# Altium schematic sheet Sheet1.SchDoc(Sheet1)
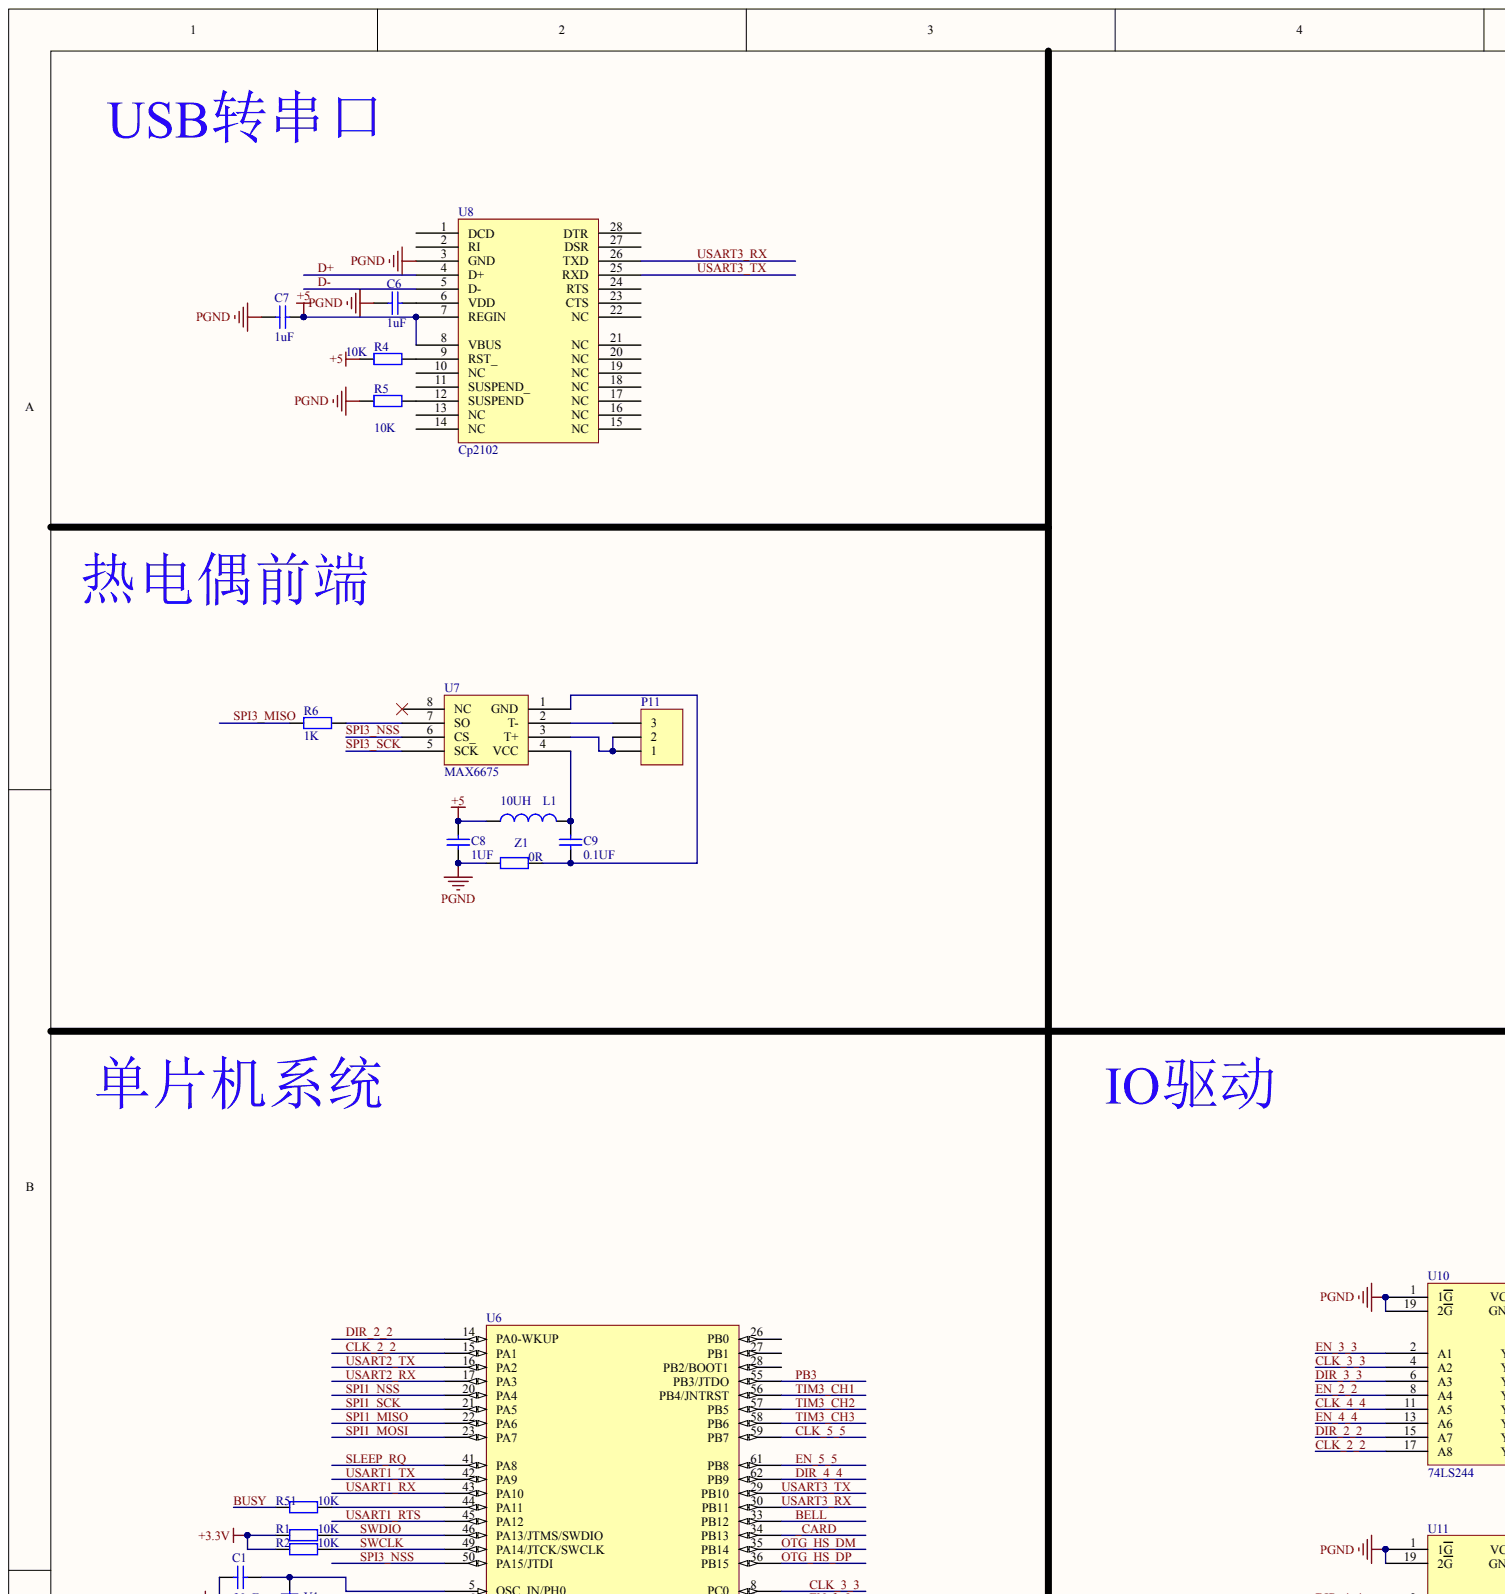
[diagram p0: Sheet1.SchDoc, top left]
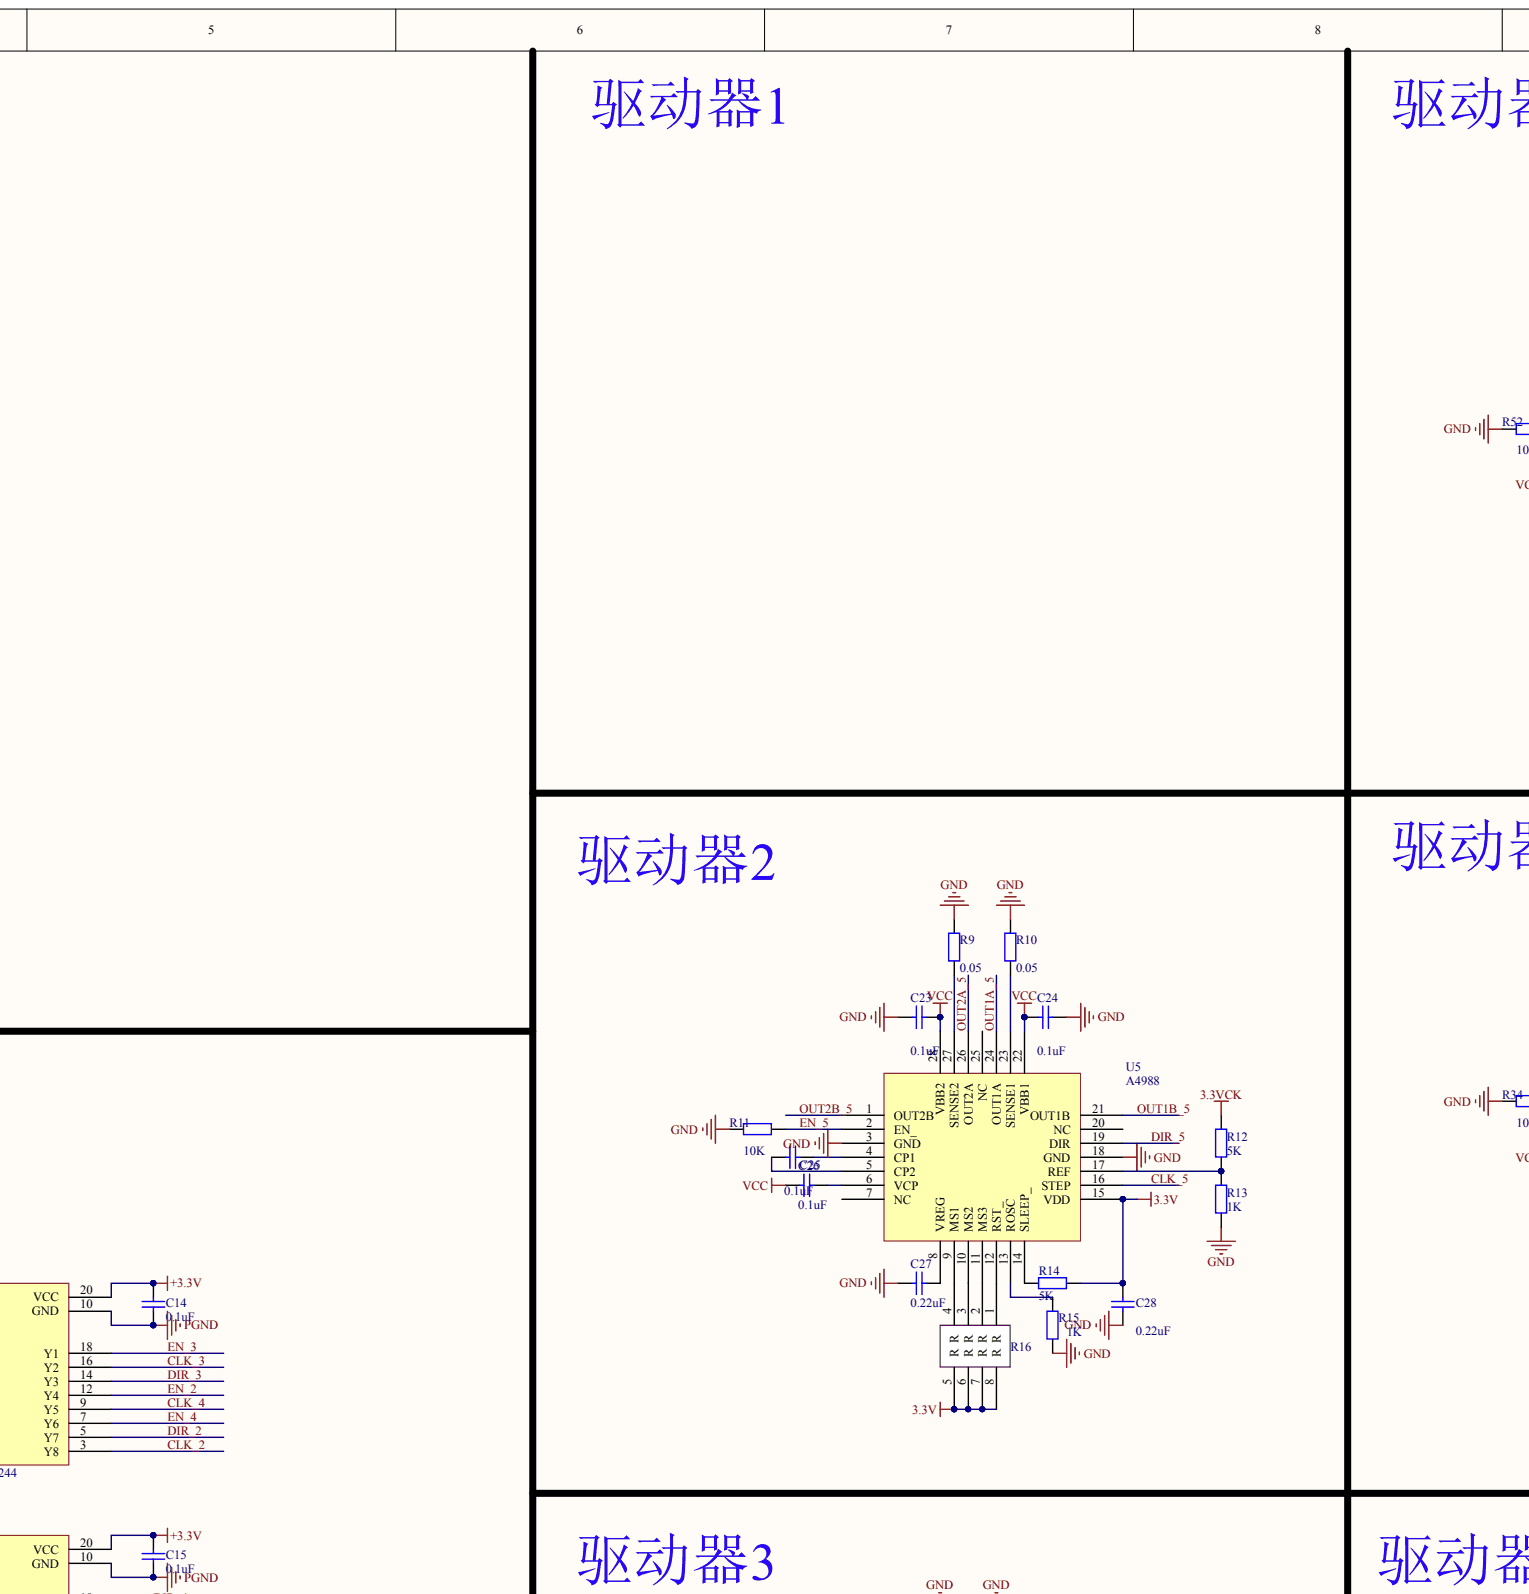
[diagram p1: Sheet1.SchDoc, top centre]
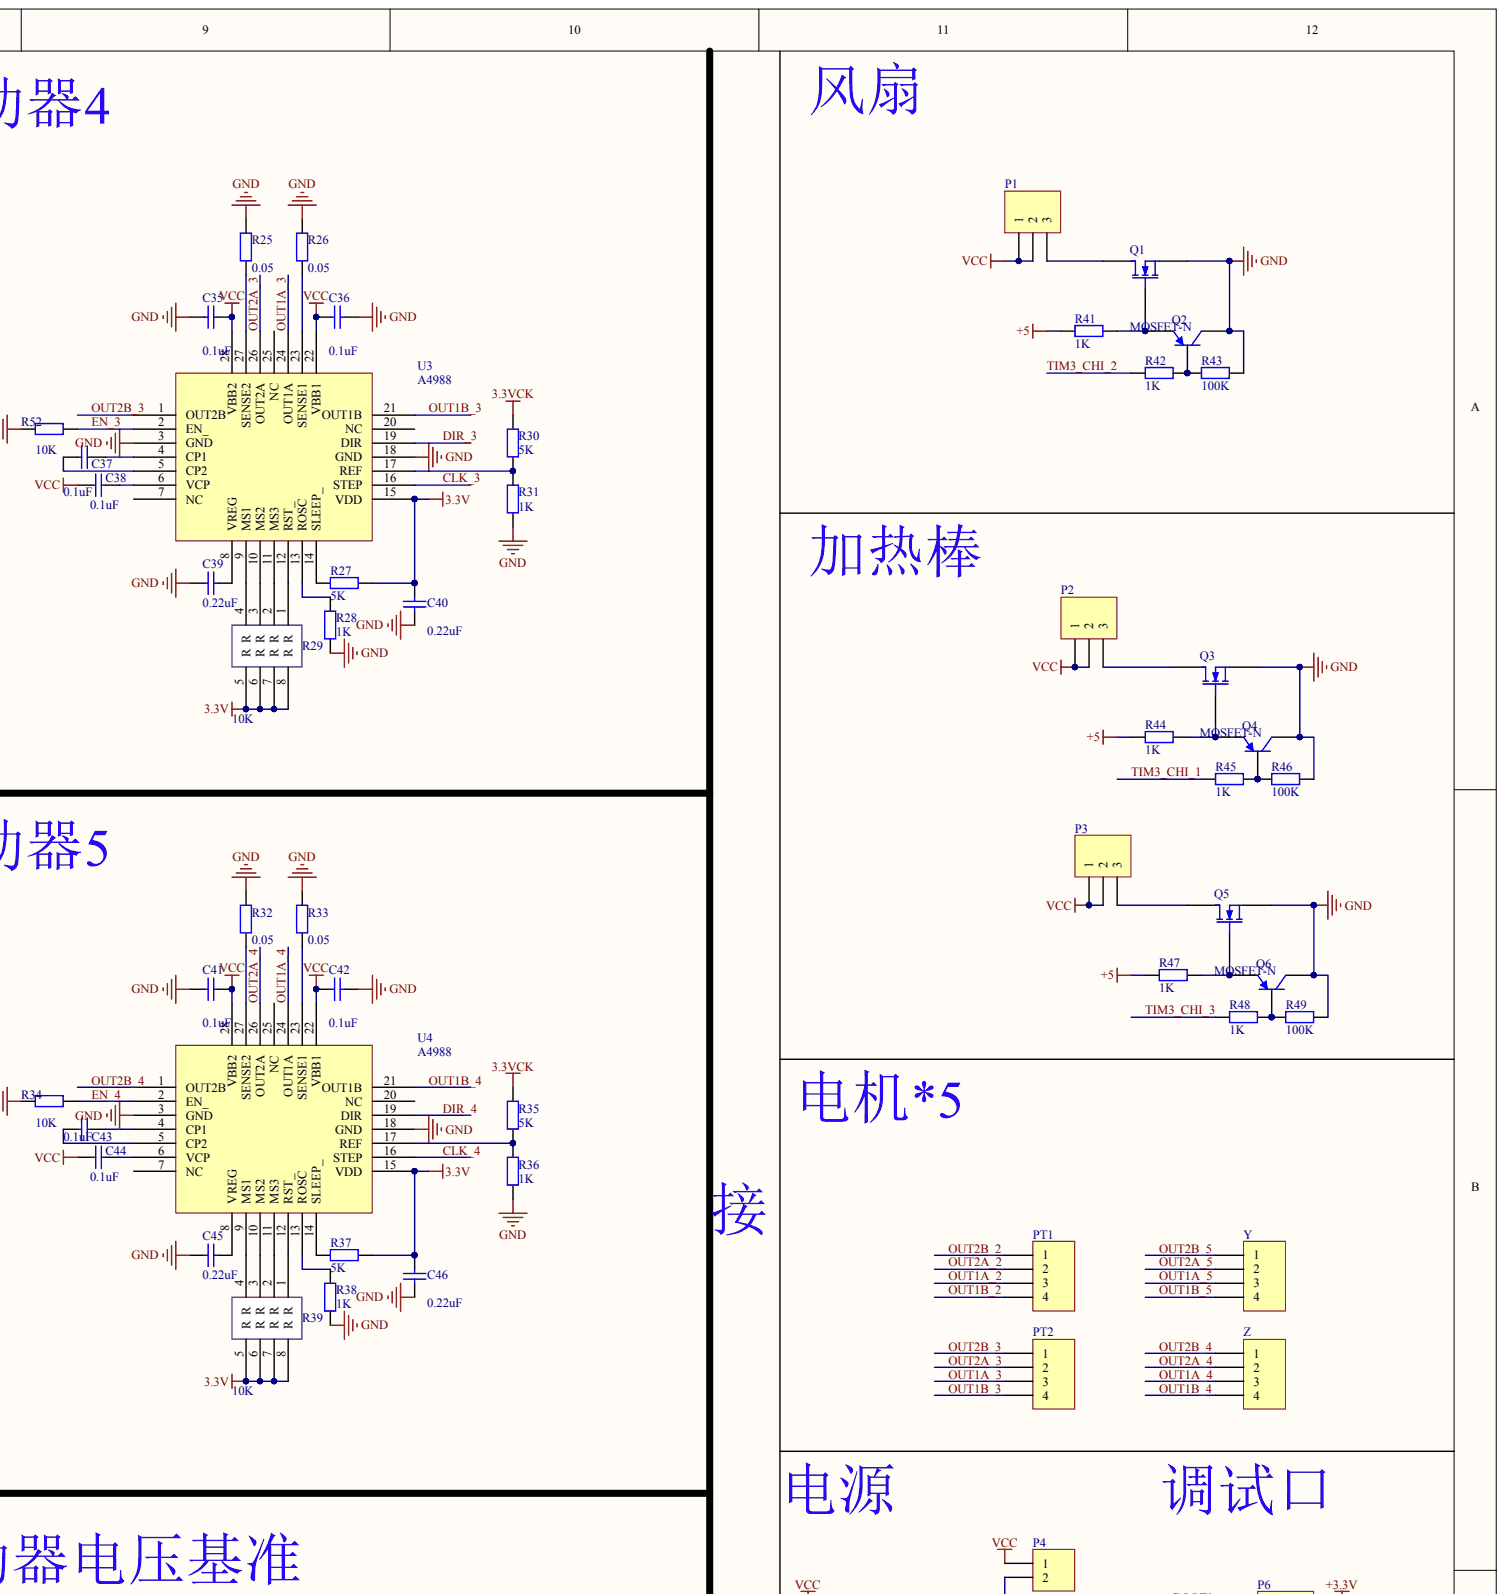
[diagram p2: Sheet1.SchDoc, top right]
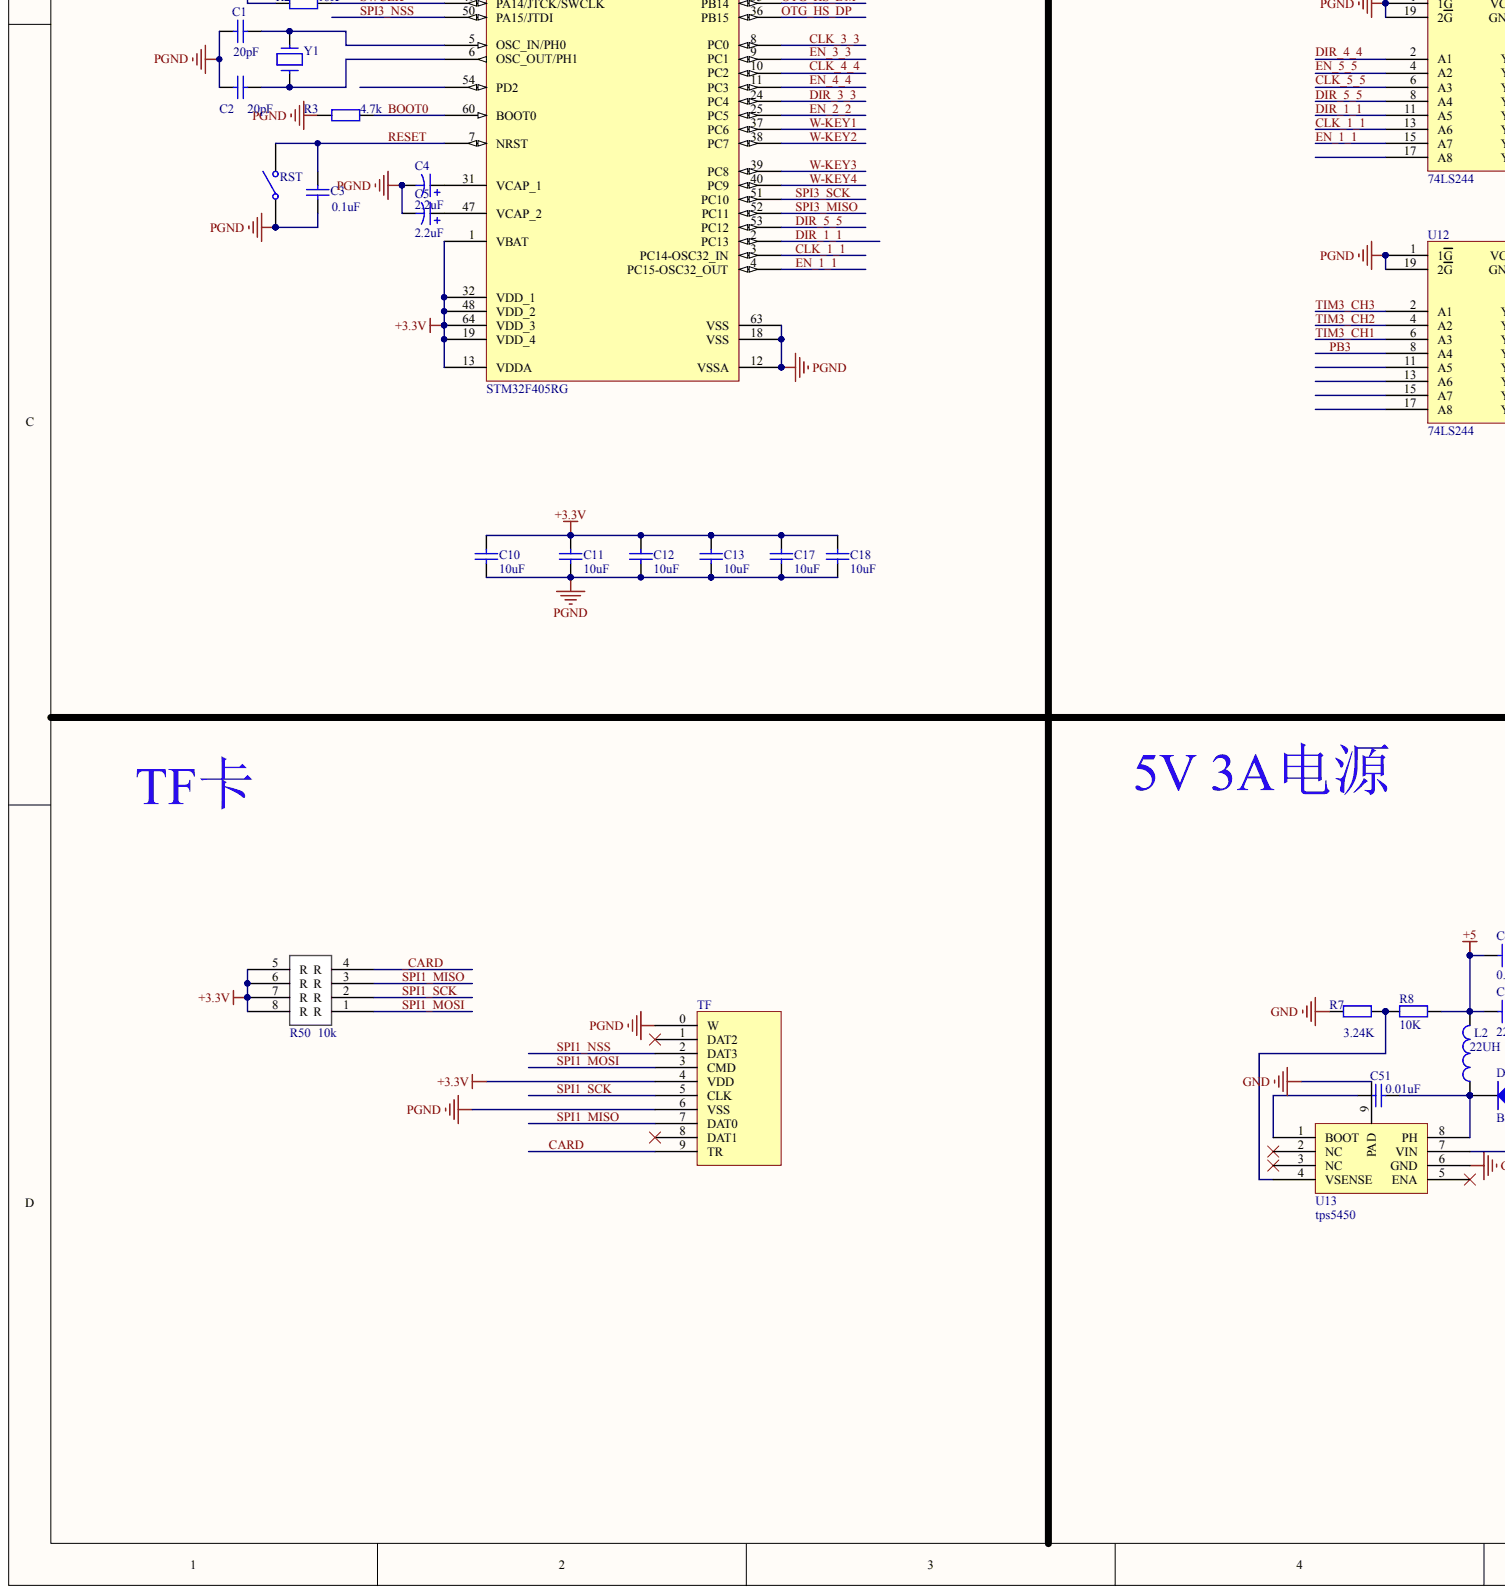
[diagram p3: Sheet1.SchDoc, bottom left]
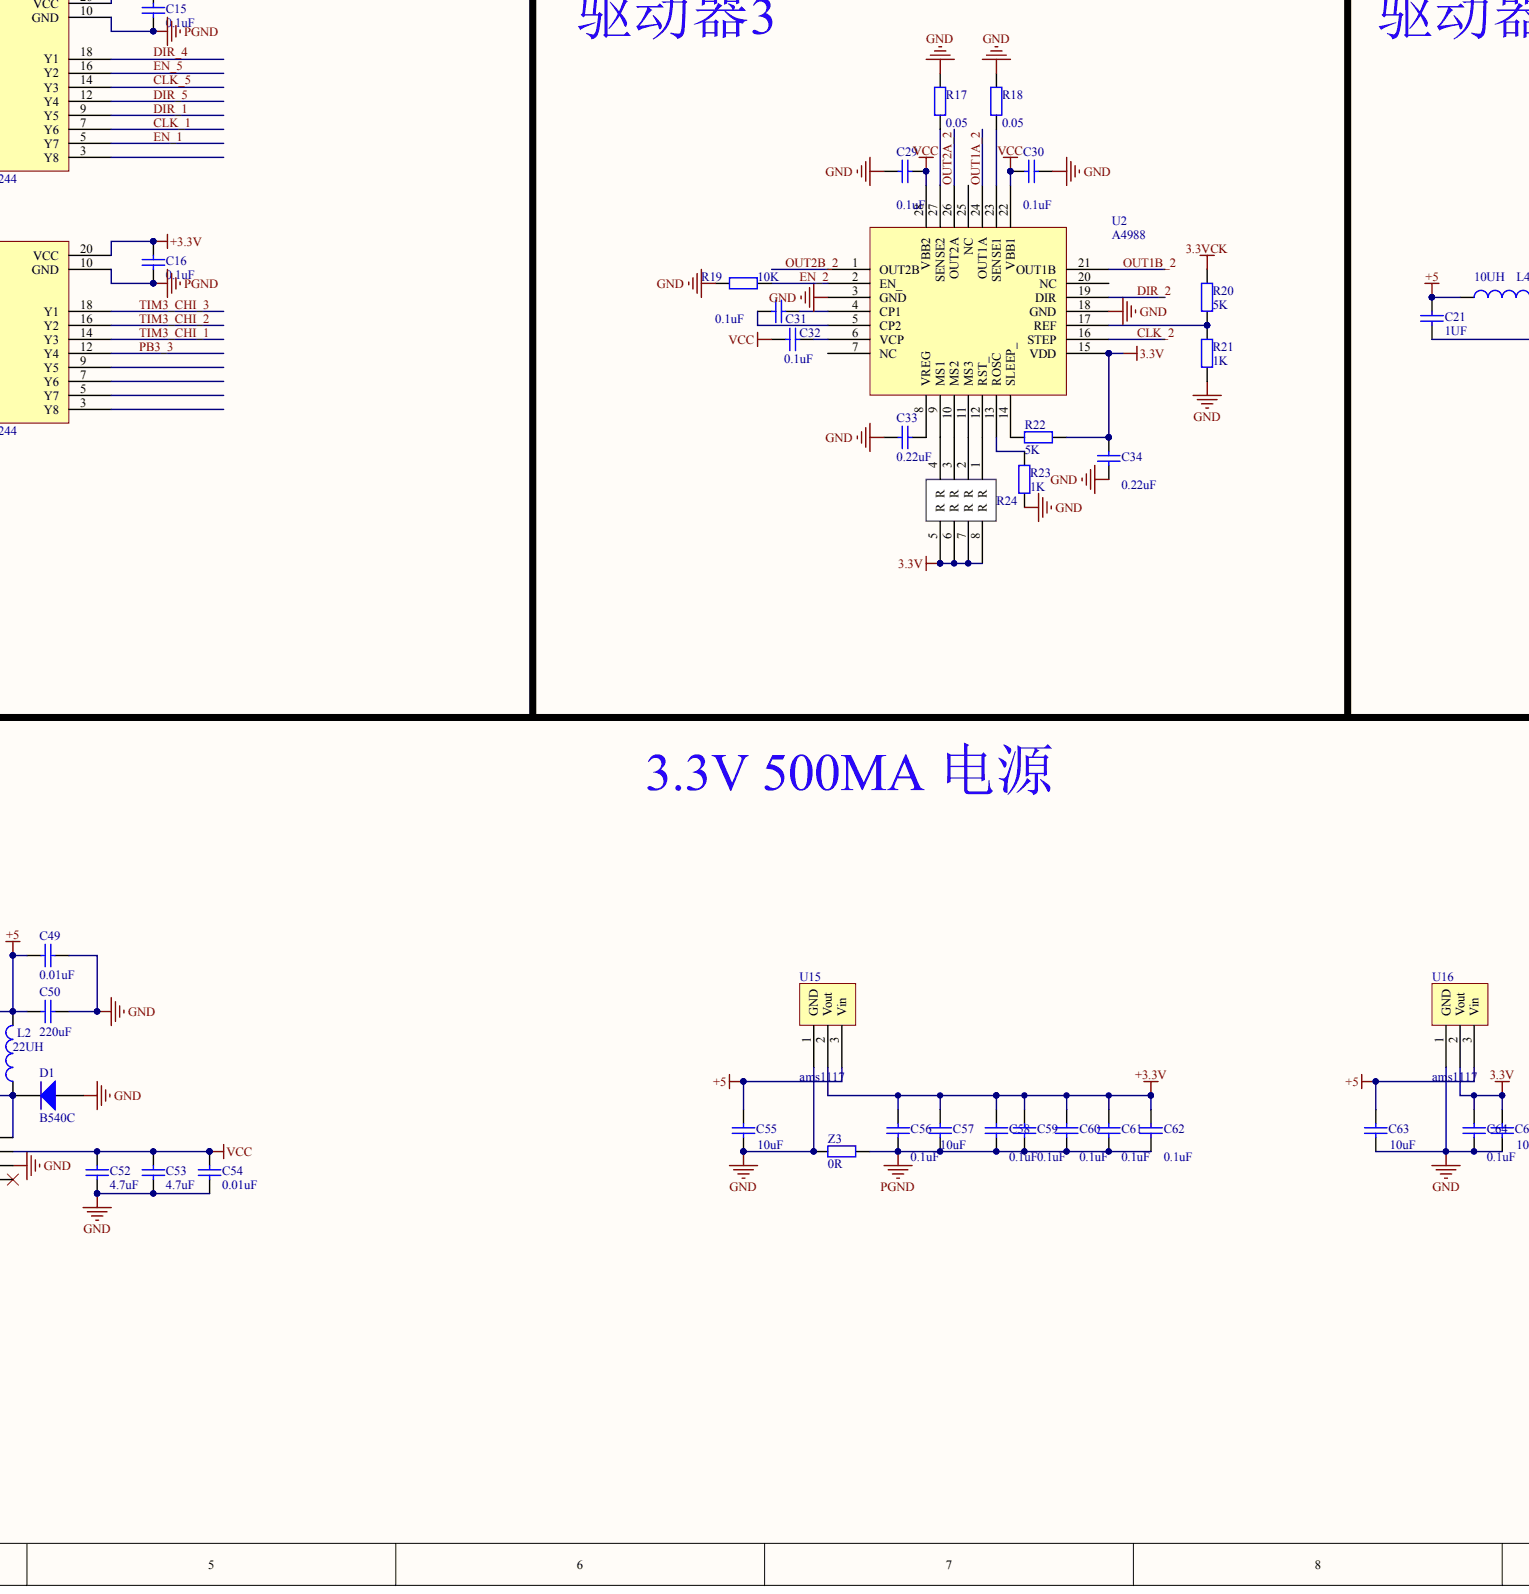
[diagram p4: Sheet1.SchDoc, bottom centre]
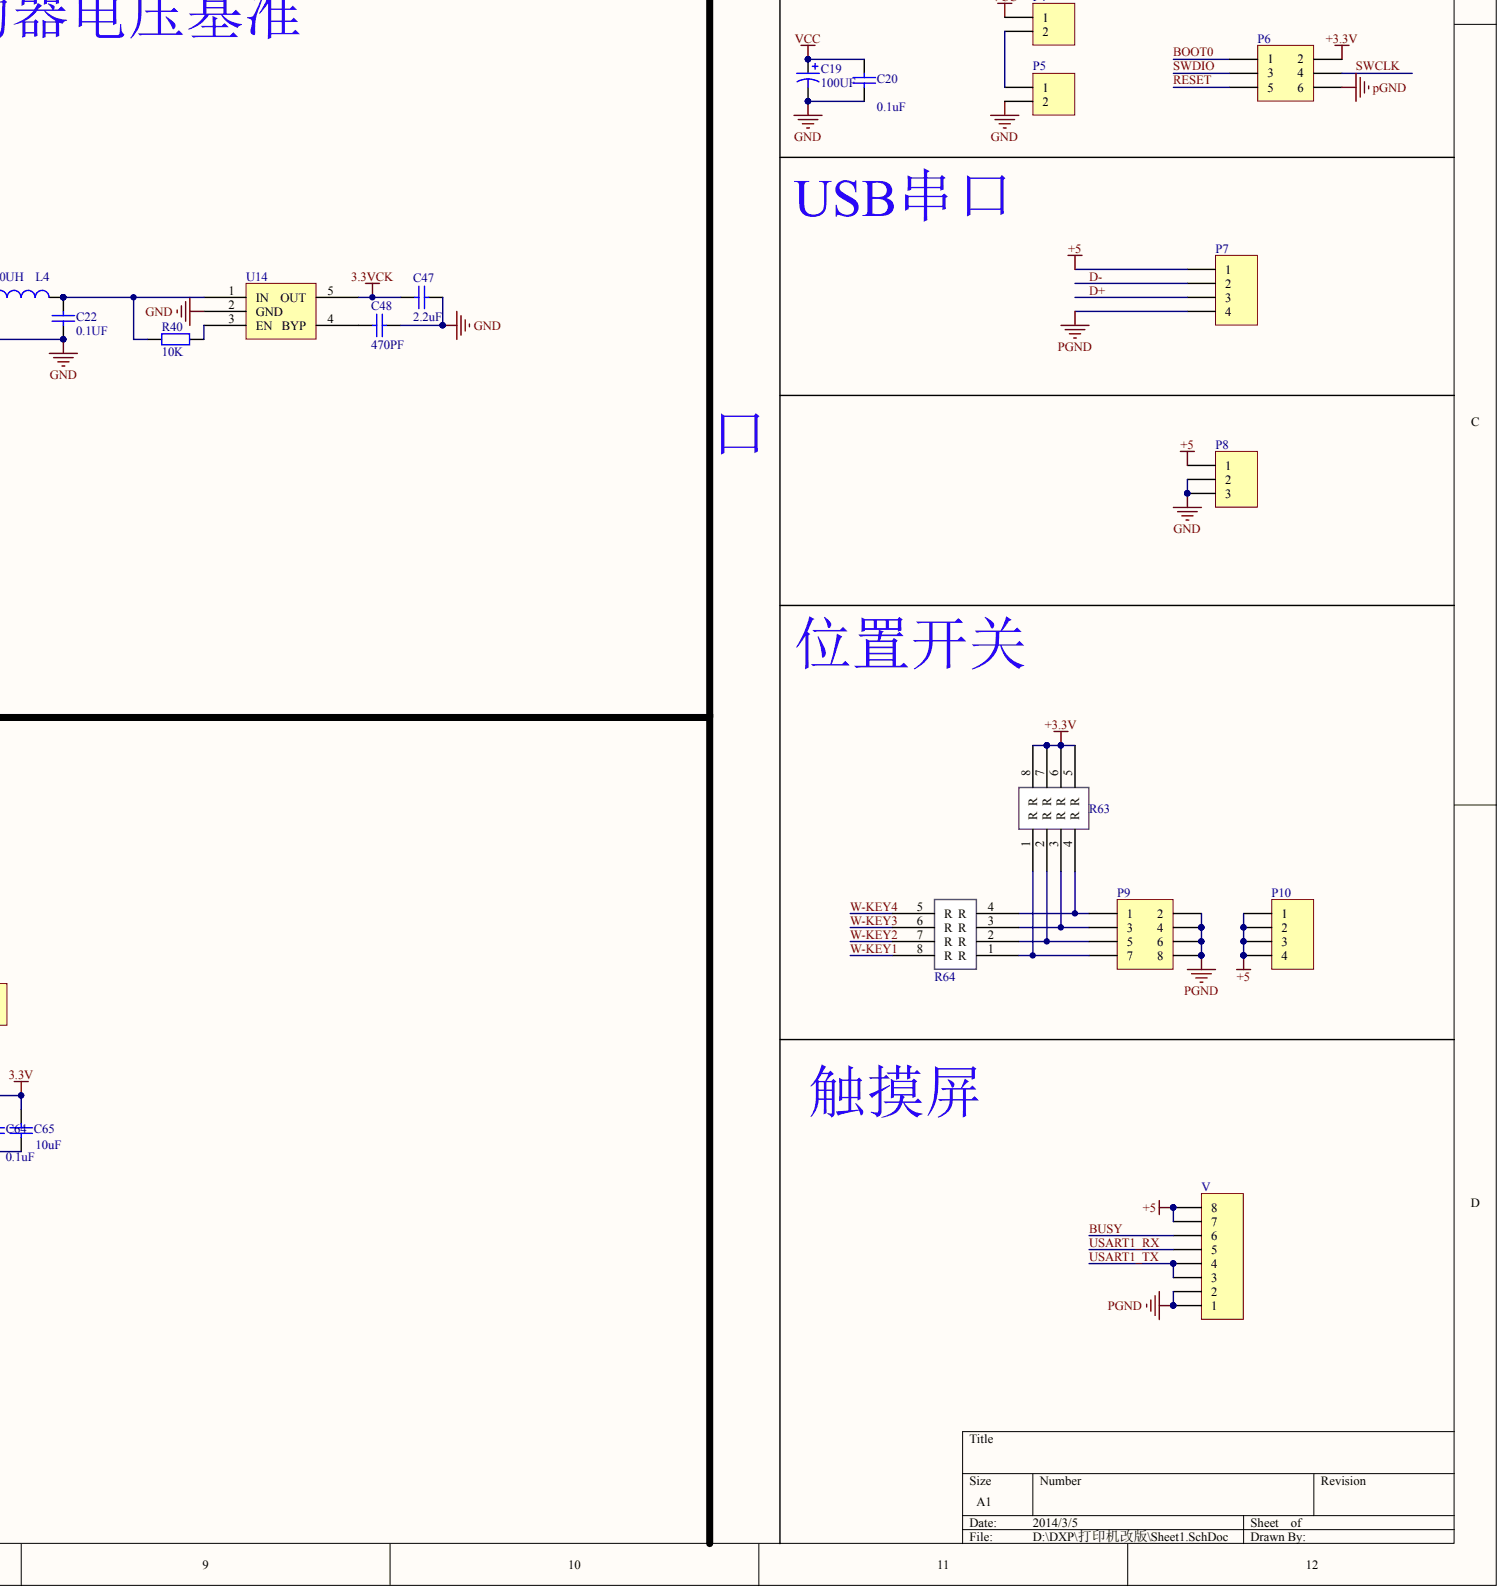
[diagram p5: Sheet1.SchDoc, bottom right]

## Parts
C1  value 20pF  (hidden comment: Cap)
C2  value 20pF  (hidden comment: Cap)
C3  value 0.1uF  (hidden comment: Cap)
C4  value 2.2uF  (hidden comment: Cap Pol1)
C5  value 2.2uF  (hidden comment: Cap Pol1)
C6  value 1uF  (hidden comment: Cap)
C7  value 1uF  (hidden comment: Cap)
C8  value 1UF  (hidden comment: Cap)
C9  value 0.1UF  (hidden comment: Cap)
C10  value 10uF  (hidden comment: Cap)
C11  value 10uF  (hidden comment: Cap)
C12  value 10uF  (hidden comment: Cap)
C13  value 10uF  (hidden comment: Cap)
C14  value 0.1uF  (hidden comment: Cap)
C15  value 0.1uF  (hidden comment: Cap)
C16  value 0.1uF  (hidden comment: Cap)
C17  value 10uF  (hidden comment: Cap)
C18  value 10uF  (hidden comment: Cap)
C19  value 100UF  (hidden comment: Cap Pol1)
C20  value 0.1uF  (hidden comment: Cap)
C21  value 1UF  (hidden comment: Cap)
C22  value 0.1UF  (hidden comment: Cap)
C23  value 0.1uF  (hidden comment: Cap)
C24  value 0.1uF  (hidden comment: Cap)
C25  value 0.1uF  (hidden comment: Cap)
C26  value 0.1uF  (hidden comment: Cap)
C27  value 0.22uF  (hidden comment: Cap)
C28  value 0.22uF  (hidden comment: Cap)
C29  value 0.1uF  (hidden comment: Cap)
C30  value 0.1uF  (hidden comment: Cap)
C31  value 0.1uF  (hidden comment: Cap)
C32  value 0.1uF  (hidden comment: Cap)
C33  value 0.22uF  (hidden comment: Cap)
C34  value 0.22uF  (hidden comment: Cap)
C35  value 0.1uF  (hidden comment: Cap)
C36  value 0.1uF  (hidden comment: Cap)
C37  value 0.1uF  (hidden comment: Cap)
C38  value 0.1uF  (hidden comment: Cap)
C39  value 0.22uF  (hidden comment: Cap)
C40  value 0.22uF  (hidden comment: Cap)
C41  value 0.1uF  (hidden comment: Cap)
C42  value 0.1uF  (hidden comment: Cap)
C43  Cap  (value not drawn)
C44  value 0.1uF  (hidden comment: Cap)
C45  value 0.22uF  (hidden comment: Cap)
C46  value 0.22uF  (hidden comment: Cap)
C47  value 2.2uF  (hidden comment: Cap)
C48  value 470PF  (hidden comment: Cap)
C49  value 0.01uF  (hidden comment: Cap)
C50  value 220uF  (hidden comment: Cap)
C51  value 0.01uF  (hidden comment: Cap)
C52  value 4.7uF  (hidden comment: Cap)
C53  value 4.7uF  (hidden comment: Cap)
C54  value 0.01uF  (hidden comment: Cap)
C55  value 10uF  (hidden comment: Cap)
C56  value 0.1uF  (hidden comment: Cap)
C57  value 10uF  (hidden comment: Cap)
C58  value 0.1uF  (hidden comment: Cap)
C59  value 0.1uF  (hidden comment: Cap)
C60  value 0.1uF  (hidden comment: Cap)
C61  value 0.1uF  (hidden comment: Cap)
C62  value 0.1uF  (hidden comment: Cap)
C63  value 10uF  (hidden comment: Cap)
C64  value 0.1uF  (hidden comment: Cap)
C65  value 10uF  (hidden comment: Cap)
D1  B540C
L1  value 10UH
L2  value 22UH  (hidden comment: Inductor)
L4  value 10UH
P1  (no value)
P2  (no value)
P3  (no value)
P4  (no value)
P5  (no value)
P6  (no value)
P7  (no value)
P8  Header 3  (value not drawn)
P9  (no value)
P10  (no value)
P11  (no value)
PT1  PH2.0-4P  (value not drawn)
PT2  PH2.0-4P  (value not drawn)
Q1  MOSFET-N
Q2  PNP  (value not drawn)
Q3  MOSFET-N
Q4  PNP  (value not drawn)
Q5  MOSFET-N
Q6  PNP  (value not drawn)
R1  value 10K  (hidden comment: Res2)
R2  value 10K  (hidden comment: Res2)
R3  value 4.7k  (hidden comment: Res2)
R4  value 10K  (hidden comment: Res2)
R5  value 10K  (hidden comment: Res2)
R6  value 1K  (hidden comment: Res2)
R7  value 3.24K  (hidden comment: Res2)
R8  value 10K  (hidden comment: Res2)
R9  value 0.05  (hidden comment: Res2)
R10  value 0.05  (hidden comment: Res2)
R11  value 10K  (hidden comment: Res2)
R12  value 5K  (hidden comment: Res2)
R13  value 1K  (hidden comment: Res2)
R14  value 5K  (hidden comment: Res2)
R15  value 1K  (hidden comment: Res2)
R16  (no value)
R17  value 0.05  (hidden comment: Res2)
R18  value 0.05  (hidden comment: Res2)
R19  value 10K  (hidden comment: Res2)
R20  value 5K  (hidden comment: Res2)
R21  value 1K  (hidden comment: Res2)
R22  value 5K  (hidden comment: Res2)
R23  value 1K  (hidden comment: Res2)
R24  (no value)
R25  value 0.05  (hidden comment: Res2)
R26  value 0.05  (hidden comment: Res2)
R27  value 5K  (hidden comment: Res2)
R28  value 1K  (hidden comment: Res2)
R29  (no value)
R30  value 5K  (hidden comment: Res2)
R31  value 1K  (hidden comment: Res2)
R32  value 0.05  (hidden comment: Res2)
R33  value 0.05  (hidden comment: Res2)
R34  value 10K  (hidden comment: Res2)
R35  value 5K  (hidden comment: Res2)
R36  value 1K  (hidden comment: Res2)
R37  value 5K  (hidden comment: Res2)
R38  value 1K  (hidden comment: Res2)
R39  (no value)
R40  value 10K  (hidden comment: Res2)
R41  value 1K  (hidden comment: Res2)
R42  value 1K  (hidden comment: Res2)
R43  value 100K  (hidden comment: Res2)
R44  value 1K  (hidden comment: Res2)
R45  value 1K  (hidden comment: Res2)
R46  value 100K  (hidden comment: Res2)
R47  value 1K  (hidden comment: Res2)
R48  value 1K  (hidden comment: Res2)
R49  value 100K  (hidden comment: Res2)
R50  (no value)
R51  value 10K  (hidden comment: Res2)
R52  value 10K  (hidden comment: Res2)
R63  Component_2  (value not drawn)
R64  Component_2  (value not drawn)
RST  SW-SPST  (value not drawn)
TF  TFCARD  (value not drawn)
U2  A4988
U3  A4988
U4  A4988
U5  A4988
U6  STM32F405RG
U7  MAX6675
U8  Cp2102
U10  74LS244
U11  74LS244
U12  74LS244
U13  tps5450
U14  MIC5205  (value not drawn)
U15  ams1117
U16  ams1117
V  (no value)
Y  PH2.0-4P  (value not drawn)
Y1  CRYSTAL  (value not drawn)
Z  PH2.0-4P  (value not drawn)
Z1  value 0R  (hidden comment: Res2)
Z3  value 0R  (hidden comment: Res2)

## Nets
3.3V: C28.2 C34.2 C40.2 C46.2 C64.2 C65.2 R14.1 R16.5(R) R16.6(R) R16.7(R) R16.8(R) R22.1 R24.5(R) R24.6(R) R24.7(R) R24.8(R) R27.1 R29.5(R) R29.6(R) R29.7(R) R29.8(R) R37.1 R39.5(R) R39.6(R) R39.7(R) R39.8(R) U2.15(VDD) U3.15(VDD) U4.15(VDD) U5.15(VDD) U16.2
3.3VCK: C47.2 R12.1 R20.1 R30.1 R35.1 U14.5(OUT)
+3.3V: C10.2 C11.2 C12.2 C13.2 C14.2 C15.2 C16.2 C17.2 C18.2 C56.2 C57.2 C58.2 C59.2 C60.2 C61.2 C62.2 P6.2 R1.2 R2.2 R50.5(R) R50.6(R) R50.7(R) R50.8(R) R63.5(R) R63.6(R) R63.7(R) R63.8(R) TF.4(VDD) U6.1(VBAT) U6.13(VDDA) U6.19(VDD_4) U6.32(VDD_1) U6.48(VDD_2) U6.64(VDD_3) U10.20(VCC) U11.20(VCC) U12.20(VCC) U15.2
+5: C7.1 C8.2 C21.2 C49.2 C50.2 C55.2 C63.2 L1.1 L2.2 L4.1 P7.1 P8.1 P10.1 P10.2 P10.3 P10.4 R4.2 R8.1 R41.2 R44.2 R47.2 U8.7(REGIN) U8.8(VBUS) U15.3 U16.3 V.7 V.8
GND: C19.2 C20.1 C21.1 C22.2 C23.1 C24.2 C27.2 C28.1 C29.1 C30.2 C33.2 C34.1 C35.1 C36.2 C39.2 C40.1 C41.1 C42.2 C45.2 C46.1 C47.1 C48.1 C49.1 C50.1 C52.1 C53.1 C54.1 C55.1 C63.1 C64.1 C65.1 D1.1 P5.2 P8.2 P8.3 Q1.3 Q2.3 Q3.3 Q4.3 Q5.3 Q6.3 R7.2 R9.2 R10.2 R11.2 R13.1 R15.1 R17.2 R18.2 R19.2 R21.1 R23.1 R25.2 R26.2 R28.1 R31.1 R32.2 R33.2 R34.2 R36.1 R38.1 R43.2 R46.2 R49.2 R52.2 U2.3(GND) U2.18(GND) U3.3(GND) U3.18(GND) U4.3(GND) U4.18(GND) U5.3(GND) U5.18(GND) U13.6(GND) U13.9(PAD) U14.2(GND) U15.1 U16.1 Z3.1
PGND: C1.1 C2.1 C3.1 C4.2 C5.2 C6.1 C7.2 C8.1 C10.1 C11.1 C12.1 C13.1 C14.1 C15.1 C16.1 C17.1 C18.1 C56.1 C57.1 C58.1 C59.1 C60.1 C61.1 C62.1 P6.6 P7.4 P9.2 P9.4 P9.6 P9.8 R3.1 R5.2 RST.1 TF.0(W) TF.6(VSS) U6.12(VSSA) U6.18(VSS) U6.63(VSS) U8.3(GND) U10.1(1G) U10.10(GND) U10.19(2G) U11.1(1G) U11.10(GND) U11.19(2G) U12.1(1G) U12.10(GND) U12.19(2G) V.1 V.2 Z1.2 Z3.2
VCC: C19.1 C20.2 C23.2 C24.1 C26.2 C29.2 C30.1 C32.2 C35.2 C36.1 C38.2 C41.2 C42.1 C44.2 C52.2 C53.2 C54.2 P1.1 P1.2 P2.1 P2.2 P3.1 P3.2 P4.1 U2.22(VBB1) U2.28(VBB2) U3.22(VBB1) U3.28(VBB2) U4.22(VBB1) U4.28(VBB2) U5.22(VBB1) U5.28(VBB2) U13.7(VIN)
_CARD: R50.4(R) TF.9(TR) U6.34(PB13)
BELL: U6.33(PB12)
BOOT0: P6.1 R3.2 U6.60(BOOT0)
BUSY: R51.2 V.6
CLK_1: U11.7(Y6)
CLK_1_1: U6.3(PC14-OSC32_IN) U11.13(A6)
CLK_2: U2.16(STEP) U10.3(Y8)
CLK_2_2: U6.15(PA1) U10.17(A8)
CLK_3: U3.16(STEP) U10.16(Y2)
CLK_3_3: U6.8(PC0) U10.4(A2)
CLK_4: U4.16(STEP) U10.9(Y5)
CLK_4_4: U6.10(PC2) U10.11(A5)
CLK_5: U5.16(STEP) U11.14(Y3)
CLK_5_5: U6.59(PB7) U11.6(A3)
D+: P7.3 U8.4(D+)
D-: P7.2 U8.5(D-)
DIR_1: U11.9(Y5)
DIR_1_1: U6.2(PC13) U11.11(A5)
DIR_2: U2.19(DIR) U10.5(Y7)
DIR_2_2: U6.14(PA0-WKUP) U10.15(A7)
DIR_3: U3.19(DIR) U10.14(Y3)
DIR_3_3: U6.24(PC4) U10.6(A3)
DIR_4: U4.19(DIR) U11.18(Y1)
DIR_4_4: U6.62(PB9) U11.2(A1)
DIR_5: U5.19(DIR) U11.12(Y4)
DIR_5_5: U6.53(PC12) U11.8(A4)
EN_1: U11.5(Y7)
EN_1_1: U6.4(PC15-OSC32_OUT) U11.15(A7)
EN_2: R19.1 U2.2(EN_) U10.12(Y4)
EN_2_2: U6.25(PC5) U10.8(A4)
EN_3: R52.1 U3.2(EN_) U10.18(Y1)
EN_3_3: U6.9(PC1) U10.2(A1)
EN_4: R34.1 U4.2(EN_) U10.7(Y6)
EN_4_4: U6.11(PC3) U10.13(A6)
EN_5: R11.1 U5.2(EN_) U11.16(Y2)
EN_5_5: U6.61(PB8) U11.4(A2)
OTG_HS_DM: U6.35(PB14)
OTG_HS_DP: U6.36(PB15)
OUT1A_2: PT1.3 U2.24(OUT1A)
OUT1A_3: PT2.3 U3.24(OUT1A)
OUT1A_4: U4.24(OUT1A) Z.3
OUT1A_5: U5.24(OUT1A) Y.3
OUT1B_2: PT1.4 U2.21(OUT1B)
OUT1B_3: PT2.4 U3.21(OUT1B)
OUT1B_4: U4.21(OUT1B) Z.4
OUT1B_5: U5.21(OUT1B) Y.4
OUT2A_2: PT1.2 U2.26(OUT2A)
OUT2A_3: PT2.2 U3.26(OUT2A)
OUT2A_4: U4.26(OUT2A) Z.2
OUT2A_5: U5.26(OUT2A) Y.2
OUT2B_2: PT1.1 U2.1(OUT2B)
OUT2B_3: PT2.1 U3.1(OUT2B)
OUT2B_4: U4.1(OUT2B) Z.1
OUT2B_5: U5.1(OUT2B) Y.1
PB3: U6.55(PB3/JTDO) U12.8(A4)
PB3_3: U12.12(Y4)
RESET: C3.2 P6.5 RST.2 U6.7(NRST)
SLEEP_RQ: U6.41(PA8)
SPI1_MISO: R50.3(R) TF.7(DAT0) U6.22(PA6)
SPI1_MOSI: R50.1(R) TF.3(CMD) U6.23(PA7)
SPI1_NSS: TF.2(DAT3) U6.20(PA4)
SPI1_SCK: R50.2(R) TF.5(CLK) U6.21(PA5)
SPI3_MISO: R6.1 U6.52(PC11)
SPI3_NSS: U6.50(PA15/JTDI) U7.6(CS_)
SPI3_SCK: U6.51(PC10) U7.5(SCK)
SWCLK: P6.4 R2.1 U6.49(PA14/JTCK/SWCLK)
SWDIO: P6.3 R1.1 U6.46(PA13/JTMS/SWDIO)
TIM3_CH1: U6.56(PB4/JNTRST) U12.6(A3)
TIM3_CH2: U6.57(PB5) U12.4(A2)
TIM3_CH3: U6.58(PB6) U12.2(A1)
TIM3_CHI_1: R45.1 U12.14(Y3)
TIM3_CHI_2: R42.1 U12.16(Y2)
TIM3_CHI_3: R48.1 U12.18(Y1)
USART1_RTS: U6.45(PA12)
USART1_RX: U6.43(PA10) V.5
USART1_TX: U6.42(PA9) V.3 V.4
USART2_RX: U6.17(PA3)
USART2_TX: U6.16(PA2)
USART3_RX: U6.30(PB11) U8.26(TXD)
USART3_TX: U6.29(PB10) U8.25(RXD)
W-KEY1: R64.8(R) U6.37(PC6)
W-KEY2: R64.7(R) U6.38(PC7)
W-KEY3: R64.6(R) U6.39(PC8)
W-KEY4: R64.5(R) U6.40(PC9)
NetC1_2: C1.2 U6.5(OSC_IN/PH0) Y1.2
NetC2_2: C2.2 U6.6(OSC_OUT/PH1) Y1.1
NetC4_1: C4.1 U6.31(VCAP_1)
NetC5_1: C5.1 U6.47(VCAP_2)
NetC6_2: C6.2 U8.6(VDD)
NetC9_1: C9.1 L1.2 U7.4(VCC)
NetC9_2: C9.2 U7.1(GND) Z1.1
NetC22_1: C22.1 L4.2 R40.2 U14.1(IN)
NetC25_1: C25.1 U5.4(CP1)
NetC25_2: C25.2 U5.5(CP2)
NetC26_1: C26.1 U5.6(VCP)
NetC27_1: C27.1 U5.8(VREG)
NetC31_1: C31.1 U2.4(CP1)
NetC31_2: C31.2 U2.5(CP2)
NetC32_1: C32.1 U2.6(VCP)
NetC33_1: C33.1 U2.8(VREG)
NetC37_1: C37.1 U3.4(CP1)
NetC37_2: C37.2 U3.5(CP2)
NetC38_1: C38.1 U3.6(VCP)
NetC39_1: C39.1 U3.8(VREG)
NetC43_1: C43.1 U4.4(CP1)
NetC43_2: C43.2 U4.5(CP2)
NetC44_1: C44.1 U4.6(VCP)
NetC45_1: C45.1 U4.8(VREG)
NetC48_2: C48.2 U14.4(BYP)
NetC51_1: C51.1 D1.2 L2.1 U13.8(PH)
NetC51_2: C51.2 U13.1(BOOT)
NetP1_3: P1.3 Q1.1
NetP2_3: P2.3 Q3.1
NetP3_3: P3.3 Q5.1
NetP4_2: P4.2 P5.1
NetP9_1: P9.1 R63.4(R) R64.4(R)
NetP9_3: P9.3 R63.3(R) R64.3(R)
NetP9_5: P9.5 R63.2(R) R64.2(R)
NetP9_7: P9.7 R63.1(R) R64.1(R)
NetP11_1: P11.1 P11.2 U7.3(T+)
NetP11_3: P11.3 U7.2(T-)
NetQ1_2: Q1.2 Q2.1 R41.1
NetQ2_2: Q2.2 R42.2 R43.1
NetQ3_2: Q3.2 Q4.1 R44.1
NetQ4_2: Q4.2 R45.2 R46.1
NetQ5_2: Q5.2 Q6.1 R47.1
NetQ6_2: Q6.2 R48.2 R49.1
NetR4_1: R4.1 U8.9(RST_)
NetR5_1: R5.1 U8.12(SUSPEND)
NetR6_2: R6.2 U7.7(SO)
NetR7_1: R7.1 R8.2 U13.4(VSENSE)
NetR9_1: R9.1 U5.27(SENSE2)
NetR10_1: R10.1 U5.23(SENSE1)
NetR12_2: R12.2 R13.2 U5.17(REF)
NetR14_2: R14.2 U5.14(SLEEP_)
NetR15_2: R15.2 U5.13(ROSC)
NetR16_1: R16.1(R) U5.12(RST_)
NetR16_2: R16.2(R) U5.11(MS3)
NetR16_3: R16.3(R) U5.10(MS2)
NetR16_4: R16.4(R) U5.9(MS1)
NetR17_1: R17.1 U2.27(SENSE2)
NetR18_1: R18.1 U2.23(SENSE1)
NetR20_2: R20.2 R21.2 U2.17(REF)
NetR22_2: R22.2 U2.14(SLEEP_)
NetR23_2: R23.2 U2.13(ROSC)
NetR24_1: R24.1(R) U2.12(RST_)
NetR24_2: R24.2(R) U2.11(MS3)
NetR24_3: R24.3(R) U2.10(MS2)
NetR24_4: R24.4(R) U2.9(MS1)
NetR25_1: R25.1 U3.27(SENSE2)
NetR26_1: R26.1 U3.23(SENSE1)
NetR27_2: R27.2 U3.14(SLEEP_)
NetR28_2: R28.2 U3.13(ROSC)
NetR29_1: R29.1(R) U3.12(RST_)
NetR29_2: R29.2(R) U3.11(MS3)
NetR29_3: R29.3(R) U3.10(MS2)
NetR29_4: R29.4(R) U3.9(MS1)
NetR30_2: R30.2 R31.2 U3.17(REF)
NetR32_1: R32.1 U4.27(SENSE2)
NetR33_1: R33.1 U4.23(SENSE1)
NetR35_2: R35.2 R36.2 U4.17(REF)
NetR37_2: R37.2 U4.14(SLEEP_)
NetR38_2: R38.2 U4.13(ROSC)
NetR39_1: R39.1(R) U4.12(RST_)
NetR39_2: R39.2(R) U4.11(MS3)
NetR39_3: R39.3(R) U4.10(MS2)
NetR39_4: R39.4(R) U4.9(MS1)
NetR40_1: R40.1 U14.3(EN)
NetR51_1: R51.1 U6.44(PA11)

Not connected: TF 1(DAT2) 8(DAT1); U2 7(NC) 20(NC) 25(NC); U3 7(NC) 20(NC) 25(NC); U4 7(NC) 20(NC) 25(NC); U5 7(NC) 20(NC) 25(NC); U6 26(PB0) 27(PB1) 28(PB2/BOOT1) 54(PD2); U7 8(NC); U8 1(DCD) 2(RI) 10(NC) 11(SUSPEND_) 13(NC) 14(NC) 15(NC) 16(NC) 17(NC) 18(NC) 19(NC) 20(NC) 21(NC) 22(NC) 23(CTS) 24(RTS) 27(DSR) 28(DTR); U11 3(Y8) 17(A8); U12 3(Y8) 5(Y7) 7(Y6) 9(Y5) 11(A5) 13(A6) 15(A7) 17(A8); U13 2(NC) 3(NC) 5(ENA)

## Library data of the parts
C23-C25 C29 C30 C35-C37 C41-C43 = (no symbol name): Value: 0.1uF; Published: 8-Jun-2000; LatestRevisionDate: 17-Jul-2002; LatestRevisionNote: Re-released for DXP Platform.; PackageReference: RAD-0.3; Publisher: Altium Limited
L1 L2 L4 = (no symbol name): Code_IEC: 1005; Published: 8-Jun-2000; LatestRevisionDate: 29-May-2009; LatestRevisionNote: IPC-7351 Footprint Added.; PackageReference: 0402-A; PackageDescription: Chip Inductor; Code_JEITA: 0402; Publisher: Altium Limited
C1-C22 C26-C28 C31-C34 C38-C40 C44-C65 D1 P1-P11 PT1 PT2 Q1-Q6 R1-R52 R63 R64 RST TF U2-U8 U10-U16 V Y Y1 Z Z1 Z3 = (no symbol name)
  C1-C3 C6-C18 C20-C22 C26-C28 C31-C34 C38-C40 C44-C65: Published: 8-Jun-2000; LatestRevisionDate: 17-Jul-2002; LatestRevisionNote: Re-released for DXP Platform.; PackageReference: RAD-0.3
  C4 C5 C19: Published: 8-Jun-2000; LatestRevisionDate: 17-Jul-2002; LatestRevisionNote: Re-released for DXP Platform.; PackageReference: RB7.6-15
  D1: Published: 8-Jun-2000; LatestRevisionDate: 17-Jul-2002; LatestRevisionNote: Re-released for DXP Platform.; PackageVersion: Sep-1996; PackageReference: SMC; Code_JEDEC: DO-214-AB
  P1-P11 PT1 PT2 V Y Z: LatestRevisionDate: 17-Jul-2002; LatestRevisionNote: Re-released for DXP Platform.
  Q1 Q3 Q5: Published: 8-Jun-2000; LatestRevisionDate: 17-Jul-2002; LatestRevisionNote: Re-released for DXP Platform.; PackageVersion: 1995/1996; PackageReference: E3
  Q2 Q4 Q6: Published: 8-Jun-2000; LatestRevisionDate: 15-Jan-2003; LatestRevisionNote: PCB Footprint 'BCY-W3/D4.7' replaced; DatasheetVersion: 1997; PackageVersion: Sep-1998; PackageReference: TO-92A; PackageDescription: TO, Flat Index; 3 In-Line, Axial Leads; Body Dia. 4.5mm; Leads 0.51 x 0.48 mm (max); ComponentLink1Description: Manufacturer Link; ComponentLink1URL: http://www.fairchildsemi.com/; ComponentLink2Description: Datasheet; ComponentLink2URL: http://www.fairchildsemi.com/ds/2N%2F2N3906.pdf
  R1-R5 R7-R15 R17-R23 R25-R28 R30-R38 R40-R42 R44 R45 R47 R48 R51 R52 Z1: Published: 8-Jun-2000; LatestRevisionDate: 17-Jul-2002; LatestRevisionNote: Re-released for DXP Platform.; PackageReference: AXIAL-0.4
  R6 R43 R46 R49 Z3: Published: 8-Jun-2000; LatestRevisionDate: 17-Jul-2002; LatestRevisionNote: Re-released for DXP Platform.; PackageReference: AXIAL-0.4; PackageDescription: Axial Device, Thru-Hole; 2 Leads; 0.4 in Pin Spacing
  R16 R50: : 10k
  R24: : 10k; : *
  R29 R39: : 10K
  RST: Published: 24-Mar-1999; LatestRevisionDate: 17-Jul-2002; LatestRevisionNote: Re-released for DXP Platform.; PackageReference: SPST-2
  C1-C22 C26-C28 C31-C34 C38-C40 C44-C65 D1 P1-P11 PT1 PT2 Q1-Q6 R1-R15 R17-R23 R25-R28 R30-R38 R40-R49 R51 R52 RST V Y Z Z1 Z3: Publisher: Altium Limited
